# Altium schematic sheet SCH - Peripheral.SchDoc
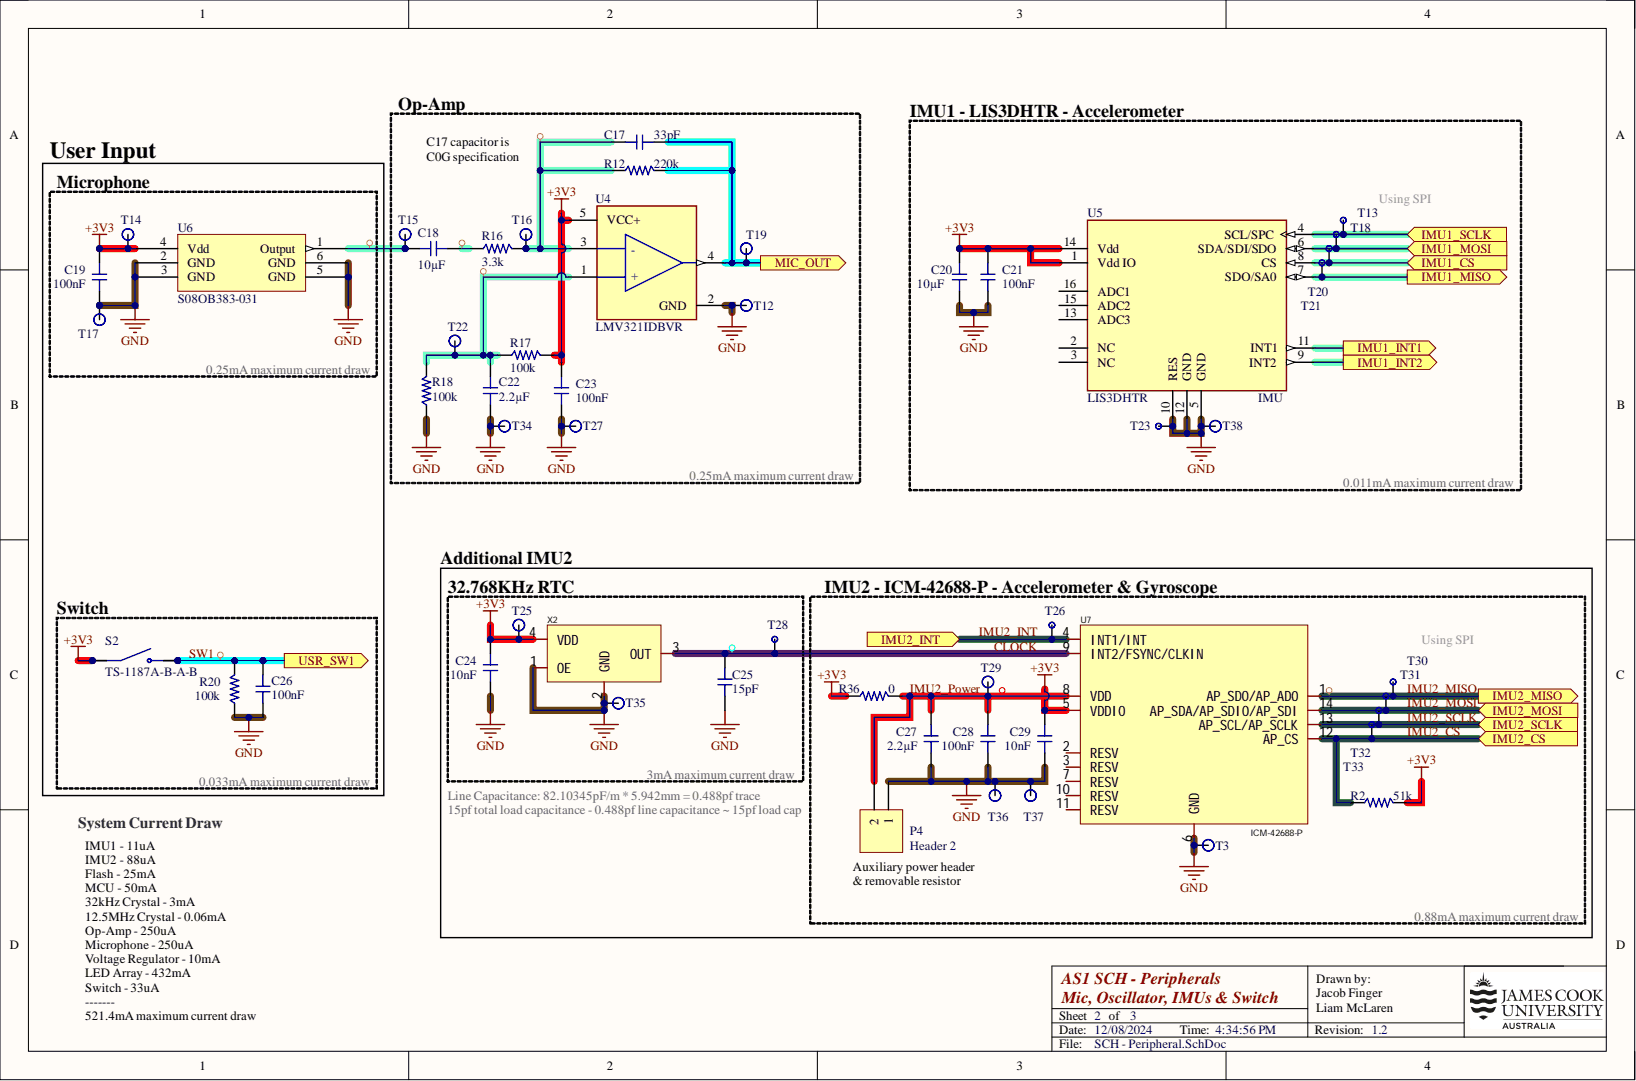
[diagram p0: SCH - Peripheral.SchDoc]

## Parts
C17  value 33pF  [CAP-0402]  (hidden comment: 0402CG330J500NT)
C18  value 10µF  [CAP-0402]  (hidden comment: CL05A106MQ5NUNC)
C19  value 100nF  [CAP-0402]  (hidden comment: CL05B104KB54PNC)
C20  value 10µF  [CAP-0402]  (hidden comment: CL05A106MQ5NUNC)
C21  value 100nF  [CAP-0402]  (hidden comment: CL05B104KB54PNC)
C22  value 2.2µF  [CAP-0402]  (hidden comment: CL05A225MQ5NSNC)
C23  value 100nF  [CAP-0402]  (hidden comment: CL05B104KB54PNC)
C24  value 10nF  [CAP-0402]  (hidden comment: CL05B103KB5NNNC)
C25  value 15pF  [CAP-0402]  (hidden comment: 0402CG150J500NT)
C26  value 100nF  [CAP-0402]  (hidden comment: CL05B104KO5NNNC)
C27  value 2.2µF  [CAP-0402]  (hidden comment: CL05A225MQ5NSNC)
C28  value 100nF  [CAP-0402]  (hidden comment: CL05B104KB54PNC)
C29  value 10nF  [CAP-0402]  (hidden comment: CL05B103KB5NNNC)
P4  Header 2  [HDR1X2]  (no BOM)
R2  value 51k  [RES-0402]  (hidden comment: 0402WGF5102TCE)
R12  value 220k  [RES-0603]  (hidden comment: 0603WAF2203T5E)
R16  value 3.3k  [RES-0402]  (hidden comment: 0402WGF3301TCE)
R17  value 100k  [RES-0402]  (hidden comment: 0402WGF1003TCE)
R18  value 100k  [RES-0402]  (hidden comment: 0402WGF1003TCE)
R20  value 100k  [RES-0402]  (hidden comment: 0402WGF1003TCE)
R36  value 0  [RES-0402]  (hidden comment: 0402WGF0000TCE)
S2  TS-1187A-B-A-B  [SW_TS-1187A-B-A-B]
T3  TP  [TestPoint]  (value not drawn)  (no BOM)
T12  TP  [TestPoint]  (value not drawn)  (no BOM)
T13  TP  [TestPoint - Small]  (value not drawn)  (no BOM)
T14  TP  [TestPoint]  (value not drawn)  (no BOM)
T15  TP  [TestPoint]  (value not drawn)  (no BOM)
T16  TP  [TestPoint]  (value not drawn)  (no BOM)
T17  TP  [TestPoint]  (value not drawn)  (no BOM)
T18  TP  [TestPoint - Small]  (value not drawn)  (no BOM)
T19  TP  [TestPoint]  (value not drawn)  (no BOM)
T20  TP  [TestPoint - Small]  (value not drawn)  (no BOM)
T21  TP  [TestPoint - Small]  (value not drawn)  (no BOM)
T22  TP  [TestPoint]  (value not drawn)  (no BOM)
T23  TP  [TestPoint - Small]  (value not drawn)  (no BOM)
T25  TP  [TestPoint]  (value not drawn)  (no BOM)
T26  TP  [TestPoint - Small]  (value not drawn)  (no BOM)
T27  TP  [TestPoint]  (value not drawn)  (no BOM)
T28  TP  [TestPoint - Small]  (value not drawn)  (no BOM)
T29  TP  [TestPoint]  (value not drawn)  (no BOM)
T30  TP  [TestPoint - Small]  (value not drawn)  (no BOM)
T31  TP  [TestPoint - Small]  (value not drawn)  (no BOM)
T32  TP  [TestPoint - Small]  (value not drawn)  (no BOM)
T33  TP  [TestPoint - Small]  (value not drawn)  (no BOM)
T34  TP  [TestPoint]  (value not drawn)  (no BOM)
T35  TP  [TestPoint]  (value not drawn)  (no BOM)
T36  TP  [TestPoint]  (value not drawn)  (no BOM)
T37  TP  [TestPoint]  (value not drawn)  (no BOM)
T38  TP  [TestPoint]  (value not drawn)  (no BOM)
U4  LMV321IDBVR  [FP-DBV0005A-MFG]
U5  LIS3DHTR  [FP-LGA-16-7983231-MFG]
U6  S08OB383-031  [Goertek_S08OB381-026]
U7  IMU IC  [LGA-14_L3.0-W2.5-P0.50-TL]  (value not drawn)
X2  HSO221S RTC  [OSC-SMD_4P-L2.5-W2.0-BL]  (value not drawn)

## Ports
IMU1_CS, IMU1_INT1, IMU1_INT2, IMU1_MISO, IMU1_MOSI, IMU1_SCLK, IMU2_CS, IMU2_INT, IMU2_MISO, IMU2_MOSI, IMU2_SCLK, MIC_OUT, USR_SW1

## Nets
+3V3: C19.2 C20.1 C21.2 C23.2 C24.2 C29.2 R2.2 R17.2 R36.1 S2.A S2.B T14.1 T25.1 U4.5(VCC+) U5.1(Vdd_IO) U5.14(Vdd) U6.4(Vdd) U7.5(VDDIO) X2.4(VDD)
GND: C19.1 C20.2 C21.1 C22.1 C23.1 C24.1 C25.1 C26.1 C27.1 C28.1 C29.1 P4.1 R18.1 R20.1 T3.1 T12.1 T17.1 T23.1 T27.1 T34.1 T35.1 T36.1 T37.1 T38.1 U4.2(GND) U5.5(GND) U5.10(RES) U5.12(GND) U6.2(GND) U6.3(GND) U6.5(GND) U6.6(GND) U7.6(GND) X2.1(OE) X2.2(GND)
CLOCK: C25.2 T28.1 U7.9(INT2/FSYNC/CLKIN) X2.3(OUT)
IMU1_CS: T20.1 U5.8(CS) ; port IMU1_CS
IMU1_INT1: U5.11(INT1) ; port IMU1_INT1
IMU1_INT2: U5.9(INT2) ; port IMU1_INT2
IMU1_MISO: T21.1 U5.7(SDO/SA0) ; port IMU1_MISO
IMU1_MOSI: T18.1 U5.6(SDA/SDI/SDO) ; port IMU1_MOSI
IMU1_SCLK: T13.1 U5.4(SCL/SPC) ; port IMU1_SCLK
IMU2_CS: R2.1 T33.1 U7.12(AP_CS) ; port IMU2_CS
IMU2_INT: T26.1 U7.4(INT1/INT) ; port IMU2_INT
IMU2_MISO: T30.1 U7.1(AP_SDO/AP_AD0) ; port IMU2_MISO
IMU2_MOSI: T31.1 U7.14(AP_SDA/AP_SDIO/AP_SDI) ; port IMU2_MOSI
IMU2_Power: C27.2 C28.2 P4.2 R36.2 T29.1 U7.8(VDD)
IMU2_SCLK: T32.1 U7.13(AP_SCL/AP_SCLK) ; port IMU2_SCLK
MIC_OUT: C17.2 R12.2 T19.1 U4.4 ; port MIC_OUT
SW1: C26.2 R20.2 S2.C S2.D ; port USR_SW1
NetC17_1: C17.1 R12.1 R16.2 T16.1 U4.3
NetC18_1: C18.1 T15.1 U6.1(Output)
NetC18_2: C18.2 R16.1
NetC22_2: C22.2 R17.1 R18.2 T22.1 U4.1

Not connected: U5 2(NC) 3(NC) 13(ADC3) 15(ADC2) 16(ADC1); U7 2(RESV) 3(RESV) 7(RESV) 10(RESV) 11(RESV)

## Library data of the parts
C17 = CMP-001-00127-3: Capacitor, Ceramic (MLCC), 33pF, ±5%, 50V, C0G, ±5%, SMD 0402; Manufacturer: FH(Guangdong Fenghua Advanced Tech); Case/Package: 0402; Category: Capacitors - MLCC; Component Kind: Standard; ComponentLink1Description: Datasheet; ComponentLink1URL: https://datasheet.lcsc.com/lcsc/1811141731_FH--Guangdong-Fenghua-Advanced-Tech-0402CG330J500NT_C1562.pdf; ComponentLink2Description: JLCPCB Part URL; ComponentLink2URL: https://jlcpcb.com/partdetail/C1562; ComponentLink3Description: LCSC Part URL; ComponentLink3URL: https://lcsc.com/product-detail/Multilayer-Ceramic-Capacitors-MLCC---SMD-SMT_FH-Guangdong-Fenghua-Advanced-Tech-FH-Guangdong-Fenghua-Advanced-Tech-0402CG330J500NT_C1562.html; Design Item ID: 0402CG330J500NT; JLCPCB Basic Part: Yes; JLCPCB Part Number: C1562; Manufacturer Part Number: 0402CG330J500NT; RoHS: Yes; Source: jlcpcb_capacitors_basic.schlib; Temperature Coefficient: C0G; Tolerance: 5%; Voltage Rating: 50V; Library Name: Finmac Systems
C18 C20 = CMP-001-00141-3: Capacitor, Ceramic (MLCC), 10uF, ±20%, 6.3V, X5R, ±20%, SMD 0402; Manufacturer: Samsung Electro-Mechanics; Case/Package: 0402; Category: Capacitors - MLCC; Component Kind: Standard; ComponentLink1Description: Datasheet; ComponentLink1URL: https://datasheet.lcsc.com/lcsc/2208231630_Samsung-Electro-Mechanics-CL05A106MQ5NUNC_C15525.pdf; ComponentLink2Description: JLCPCB Part URL; ComponentLink2URL: https://jlcpcb.com/partdetail/C15525; ComponentLink3Description: LCSC Part URL; ComponentLink3URL: https://lcsc.com/product-detail/Multilayer-Ceramic-Capacitors-MLCC---SMD-SMT_Samsung-Electro-Mechanics-Samsung-Electro-Mechanics-CL05A106MQ5NUNC_C15525.html; Design Item ID: CL05A106MQ5NUNC; JLCPCB Basic Part: Yes; JLCPCB Part Number: C15525; Manufacturer Part Number: CL05A106MQ5NUNC; RoHS: Yes; Source: jlcpcb_capacitors_basic.schlib; Temperature Coefficient: X5R; Tolerance: 20%; Voltage Rating: 6.3V; Library Name: Finmac Systems
C19 C21 C23 C28 = CMP-001-00146-3: Capacitor, Ceramic (MLCC), 100nF, ±10%, 50V, X7R, ±10%, SMD 0402; Manufacturer: Samsung Electro-Mechanics; Case/Package: 0402; Category: Capacitors - MLCC; Component Kind: Standard; ComponentLink1Description: Datasheet; ComponentLink1URL: https://datasheet.lcsc.com/lcsc/1810191222_Samsung-Electro-Mechanics-CL05B104KB54PNC_C307331.pdf; ComponentLink2Description: JLCPCB Part URL; ComponentLink2URL: https://jlcpcb.com/partdetail/C307331; ComponentLink3Description: LCSC Part URL; ComponentLink3URL: https://lcsc.com/product-detail/Multilayer-Ceramic-Capacitors-MLCC---SMD-SMT_Samsung-Electro-Mechanics-Samsung-Electro-Mechanics-CL05B104KB54PNC_C307331.html; Design Item ID: CL05B104KB54PNC; JLCPCB Basic Part: Yes; JLCPCB Part Number: C307331; Manufacturer Part Number: CL05B104KB54PNC; RoHS: Yes; Source: jlcpcb_capacitors_basic.schlib; Temperature Coefficient: X7R; Tolerance: 10%; Voltage Rating: 50V; Library Name: Finmac Systems
C22 C27 = CMP-001-00142-3: Capacitor, Ceramic (MLCC), 2.2uF, ±20%, 6.3V, X5R, ±20%, SMD 0402; Manufacturer: Samsung Electro-Mechanics; Case/Package: 0402; Category: Capacitors - MLCC; Component Kind: Standard; ComponentLink1Description: Datasheet; ComponentLink1URL: https://datasheet.lcsc.com/lcsc/1811021410_Samsung-Electro-Mechanics-CL05A225MQ5NSNC_C12530.pdf; ComponentLink2Description: JLCPCB Part URL; ComponentLink2URL: https://jlcpcb.com/partdetail/C12530; ComponentLink3Description: LCSC Part URL; ComponentLink3URL: https://lcsc.com/product-detail/Multilayer-Ceramic-Capacitors-MLCC---SMD-SMT_Samsung-Electro-Mechanics-Samsung-Electro-Mechanics-CL05A225MQ5NSNC_C12530.html; Design Item ID: CL05A225MQ5NSNC; JLCPCB Basic Part: Yes; JLCPCB Part Number: C12530; Manufacturer Part Number: CL05A225MQ5NSNC; RoHS: Yes; Source: jlcpcb_capacitors_basic.schlib; Temperature Coefficient: X5R; Tolerance: 20%; Voltage Rating: 6.3V; Library Name: Finmac Systems
C24 C29 = CMP-001-00145-3: Capacitor, Ceramic (MLCC), 10nF, ±10%, 50V, X7R, ±10%, SMD 0402; Manufacturer: Samsung Electro-Mechanics; Case/Package: 0402; Category: Capacitors - MLCC; Component Kind: Standard; ComponentLink1Description: Datasheet; ComponentLink1URL: https://datasheet.lcsc.com/lcsc/1810191223_Samsung-Electro-Mechanics-CL05B103KB5NNNC_C15195.pdf; ComponentLink2Description: JLCPCB Part URL; ComponentLink2URL: https://jlcpcb.com/partdetail/C15195; ComponentLink3Description: LCSC Part URL; ComponentLink3URL: https://lcsc.com/product-detail/Multilayer-Ceramic-Capacitors-MLCC---SMD-SMT_Samsung-Electro-Mechanics-Samsung-Electro-Mechanics-CL05B103KB5NNNC_C15195.html; Design Item ID: CL05B103KB5NNNC; JLCPCB Basic Part: Yes; JLCPCB Part Number: C15195; Manufacturer Part Number: CL05B103KB5NNNC; RoHS: Yes; Source: jlcpcb_capacitors_basic.schlib; Temperature Coefficient: X7R; Tolerance: 10%; Voltage Rating: 50V; Library Name: Finmac Systems
C25 = CMP-001-00117-3: Capacitor, Ceramic (MLCC), 15pF, ±5%, 50V, C0G, ±5%, SMD 0402; Manufacturer: FH(Guangdong Fenghua Advanced Tech); Case/Package: 0402; Category: Capacitors - MLCC; Component Kind: Standard; ComponentLink1Description: Datasheet; ComponentLink1URL: https://datasheet.lcsc.com/lcsc/1810111610_FH--Guangdong-Fenghua-Advanced-Tech-0402CG150J500NT_C1548.pdf; ComponentLink2Description: JLCPCB Part URL; ComponentLink2URL: https://jlcpcb.com/partdetail/C1548; ComponentLink3Description: LCSC Part URL; ComponentLink3URL: https://lcsc.com/product-detail/Multilayer-Ceramic-Capacitors-MLCC---SMD-SMT_FH-Guangdong-Fenghua-Advanced-Tech-FH-Guangdong-Fenghua-Advanced-Tech-0402CG150J500NT_C1548.html; Design Item ID: 0402CG150J500NT; JLCPCB Basic Part: Yes; JLCPCB Part Number: C1548; Manufacturer Part Number: 0402CG150J500NT; RoHS: Yes; Source: jlcpcb_capacitors_basic.schlib; Temperature Coefficient: C0G; Tolerance: 5%; Voltage Rating: 50V; Library Name: Finmac Systems
C26 = CMP-001-00147-3: Capacitor, Ceramic (MLCC), 100nF, ±10%, 16V, X7R, ±10%, SMD 0402; Manufacturer: Samsung Electro-Mechanics; Case/Package: 0402; Category: Capacitors - MLCC; Component Kind: Standard; ComponentLink1Description: Datasheet; ComponentLink1URL: https://datasheet.lcsc.com/lcsc/1810191219_Samsung-Electro-Mechanics-CL05B104KO5NNNC_C1525.pdf; ComponentLink2Description: JLCPCB Part URL; ComponentLink2URL: https://jlcpcb.com/partdetail/C1525; ComponentLink3Description: LCSC Part URL; ComponentLink3URL: https://lcsc.com/product-detail/Multilayer-Ceramic-Capacitors-MLCC---SMD-SMT_Samsung-Electro-Mechanics-Samsung-Electro-Mechanics-CL05B104KO5NNNC_C1525.html; Design Item ID: CL05B104KO5NNNC; JLCPCB Basic Part: Yes; JLCPCB Part Number: C1525; Manufacturer Part Number: CL05B104KO5NNNC; RoHS: Yes; Source: jlcpcb_capacitors_basic.schlib; Temperature Coefficient: X7R; Tolerance: 10%; Voltage Rating: 16V; Library Name: Finmac Systems
P4 = CMP-002-00097-1: Header, 2-Pin; Component Type: Standard; Design Item ID: Header 2; LatestRevisionDate: 17-Jul-2002; LatestRevisionNote: Re-released for DXP Platform.; Pin Count: 2; Signal Integrity: Connector; Source: Miscellaneous Connectors.SchLib; Library Name: James Cook University; Publisher: Altium Limited
R2 = CMP-009-00226-4: Resistor, 51kΩ, ±1%, 62.5mW, 50V, ±100ppm/℃, -55℃~+155℃, SMD 0402; Manufacturer: Uniroyal; Case/Package: 0402; Category: Resistors; Component Kind: Standard; ComponentLink1Description: Datasheet; ComponentLink1URL: https://datasheet.lcsc.com/lcsc/2206010100_UNI-ROYAL-Uniroyal-Elec-0402WGF5102TCE_C25794.pdf; ComponentLink2Description: JLCPCB Part URL; ComponentLink2URL: https://jlcpcb.com/partdetail/C25794; Design Item ID: 0402WGF5102TCE; JLCPCB Basic Part: Yes; JLCPCB Part Number: C25794; Manufacturer Part Number: 0402WGF5102TCE; Power Rating: 62.5mW; Source: jlcpcb_resistors_basic.schlib; Temperature Coefficient: ±100ppm/℃; Temperature Rating: -55℃~+155℃; Tolerance: 1%; Voltage Rating: 50V; Library Name: Finmac Systems
R12 = CMP-009-00277-2: Resistor, 220kΩ, ±1%, 100mW, 75V, ±100ppm/℃, -55℃~+155℃, SMD 0603; Manufacturer: Uniroyal; Case/Package: 0603; Category: Resistors; Component Kind: Standard; ComponentLink1Description: Datasheet; ComponentLink1URL: https://datasheet.lcsc.com/lcsc/2206010130_UNI-ROYAL-Uniroyal-Elec-0603WAF2203T5E_C22961.pdf; ComponentLink2Description: JLCPCB Part URL; ComponentLink2URL: https://jlcpcb.com/partdetail/C22961; Design Item ID: 0603WAF2203T5E; JLCPCB Basic Part: Yes; JLCPCB Part Number: C22961; Manufacturer Part Number: 0603WAF2203T5E; Power Rating: 100mW; Source: jlcpcb_resistors_basic.schlib; Temperature Coefficient: ±100ppm/℃; Temperature Rating: -55℃~+155℃; Tolerance: 1%; Voltage Rating: 75V; Library Name: Finmac Systems
R16 = CMP-009-00211-4: Resistor, 3.3kΩ, ±1%, 62.5mW, 50V, ±100ppm/℃, -55℃~+155℃, SMD 0402; Manufacturer: Uniroyal; Case/Package: 0402; Category: Resistors; Component Kind: Standard; ComponentLink1Description: Datasheet; ComponentLink1URL: https://datasheet.lcsc.com/lcsc/2206010045_UNI-ROYAL-Uniroyal-Elec-0402WGF3301TCE_C25890.pdf; ComponentLink2Description: JLCPCB Part URL; ComponentLink2URL: https://jlcpcb.com/partdetail/C25890; Design Item ID: 0402WGF3301TCE; JLCPCB Basic Part: Yes; JLCPCB Part Number: C25890; Manufacturer Part Number: 0402WGF3301TCE; Power Rating: 62.5mW; Source: jlcpcb_resistors_basic.schlib; Temperature Coefficient: ±100ppm/℃; Temperature Rating: -55℃~+155℃; Tolerance: 1%; Voltage Rating: 50V; Library Name: Finmac Systems
R17 R18 R20 = CMP-009-00182-4: Resistor, 100kΩ, ±1%, 62.5mW, 50V, ±100ppm/℃, -55℃~+155℃, SMD 0402; Manufacturer: Uniroyal; Case/Package: 0402; Category: Resistors; Component Kind: Standard; ComponentLink1Description: Datasheet; ComponentLink1URL: https://datasheet.lcsc.com/lcsc/2206010100_UNI-ROYAL-Uniroyal-Elec-0402WGF1003TCE_C25741.pdf; ComponentLink2Description: JLCPCB Part URL; ComponentLink2URL: https://jlcpcb.com/partdetail/C25741; Design Item ID: 0402WGF1003TCE; JLCPCB Basic Part: Yes; JLCPCB Part Number: C25741; Manufacturer Part Number: 0402WGF1003TCE; Power Rating: 62.5mW; Source: jlcpcb_resistors_basic.schlib; Temperature Coefficient: ±100ppm/℃; Temperature Rating: -55℃~+155℃; Tolerance: 1%; Voltage Rating: 50V; Library Name: Finmac Systems
R36 = CMP-009-00178-4: Resistor, 0Ω, ±1%, 62.5mW, 50V, ±800ppm/℃, -55℃~+155℃, SMD 0402; Manufacturer: Uniroyal; Case/Package: 0402; Category: Resistors; Component Kind: Standard; ComponentLink1Description: Datasheet; ComponentLink1URL: https://datasheet.lcsc.com/lcsc/2206010216_UNI-ROYAL-Uniroyal-Elec-0402WGF0000TCE_C17168.pdf; ComponentLink2Description: JLCPCB Part URL; ComponentLink2URL: https://jlcpcb.com/partdetail/C17168; Design Item ID: 0402WGF0000TCE; JLCPCB Basic Part: Yes; JLCPCB Part Number: C17168; Manufacturer Part Number: 0402WGF0000TCE; Power Rating: 62.5mW; Source: jlcpcb_resistors_basic.schlib; Temperature Coefficient: ±800ppm/℃; Temperature Rating: -55℃~+155℃; Tolerance: 1%; Voltage Rating: 50V; Library Name: Finmac Systems
S2 = CMP-015-000000-1: SPST 50mA @ 12VDC Top Actuated Round Button 160gf SMD Tactile Switches RoHS; Availability: Unavailable; Component Kind: Standard; Component Type: Standard; Design Item ID: TS-1187A-B-A-B; JLCPCB Part: C318884; MP: TS-1187A-B-A-B; Package: None; Pin Count: 4; Price: None; Source: TS-1187A-B-A-B.SchLib; Library Name: Finmac Systems
T3 T12 T14-T17 T19 T22 T25 T27 T29 T34-T38 = TestPoint: Library Name: DatumPenLibrary.SchLib
T13 T18 T20 T21 T23 T26 T28 T30-T33 = TestPoint Small: Library Name: DatumPenLibrary.SchLib
U4 = CMP-00012-2: General Purpose Amplifier 1 Circuit Rail-to-Rail SOT-23-5; Bandwidth: 1MHz; Common Mode Rejection Ratio: 50dB; Input Bias Current: 250nA; Input Offset Voltage (Vos): 7mV; Max Supply Voltage: 5.5V; Min Supply Voltage: 2.7V; Nominal Supply Current: 250uA; Number of Amplifiers: 1; Operating Supply Current: 130uA; Output Current: 160mA; Slew Rate: 1V/µs; Voltage Gain: 100dB; Amplifier Type: GENERAL PURPOSE; Case/Package: SOT-23-5; Component Kind: Standard; Contact Plating: Tin,Matte; Gain Bandwidth Product: 1MHz; JLCPCB Part: C7972; Lead Free: Lead Free; Max Operating Temperature: 125°C; Min Operating Temperature: -40°C; Mount: Surface Mount; Number of Channels: 1; Number of Circuits: 1; Number of Elements: 1; Number of Pins: 5; Output Current per Channel: 160mA; Package Quantity: 1; Packaging: Tape and Reel; Power Supply Rejection Ratio (PSRR): 50dB; Radiation Hardening: No; REACH SVHC: No SVHC; RoHS Compliant: Yes; Series: -; Supply Current: 250uA; Technology: BICMOS; Unity Gain Bandwidth Product: 1MHz; Library Name: SCH_LIB_Assignment1.SchLib
U5 = CMP-00010-2: ACCEL 2-16G I2C/SPI 16LGA; Axis: X, Y, Z; Bandwidth: 5kHz; Interface: I2C; Max Supply Voltage: 3.6V; Min Supply Voltage: 1.71V; Operating Supply Current: 11uA; Operating Supply Voltage: 2.5V; Output Type: Digital; Resolution: 16b; Sensor Type: 3 Axis; Case/Package: LGA; Component Kind: Standard; Contact Plating: Gold; Depth: 3mm; Height: 1mm; JLCPCB Part: C15134; Lead Free: Lead Free; Length: 3mm; Max Operating Temperature: 85°C; Max Supply Voltage (DC): 3.6V; Min Operating Temperature: -40°C; Min Supply Voltage (DC): 1.71V; Number of Pins: 16; Package Quantity: 1; Packaging: Tape and Reel; Radiation Hardening: No; REACH SVHC: No SVHC; RoHS Compliant: Yes; Series: -; Width: 3mm; Library Name: SCH_LIB_Assignment1.SchLib
U6 = CMP-00027-00000-1: MEMS Microphone SMD 3.76x3x1.1mm; Component Kind: Standard; JLCPCB Part: C233789; Library Name: SCH_LIB_Assignment1.SchLib
U7 = ICM-42688-P: ICM-42688-P LGA-14(2.5x3) Attitude Sensor/Gyroscope; Manufacturer: TDK InvenSense(应美盛); Add into BOM: yes; Sensor Type: 加速度计陀螺仪; Component Kind: Standard; Convert to PCB: yes; Datasheet: https://atta.szlcsc.com/upload/public/pdf/source/20210703/CF24204867ACE5B754A2B035F23E5931.pdf; Device: ICM-42688-P; JLCPCB Part #: C1850418; JLCPCB Part Class: Extended Part; LCSC Part #: C1850418; LCSC Part Name: ICM-42688-P; Manufacturer Part: ICM-42688-P; Supplier: LCSC; Supplier Footprint: LGA-14(2.5x3); Supplier Part: C1850418; Symbol: ICM-42688-P; Library Name: DatumPenLibrary.SchLib
X2 = S2H32768KF3CHC-IT: HSO221S RTC - 3.3V 3mA ±30ppm 32.768kHz SMD2520-4P Oscillators ROHS; Manufacturer: HELE(台湾加高); Add into BOM: yes; Component Kind: Standard; Convert to PCB: yes; Datasheet: https://atta.szlcsc.com/upload/public/pdf/source/20190430/C253914_C770A64EFF6E6E62846100D666617C6C.pdf; Device: S2H32768KF3CHC-IT; Frequency Stability(Full temperature range): ±30ppm; JLCPCB Part #: C253914; JLCPCB Part Class: Extended Part; LCSC Part #: C253914; LCSC Part Name: S2H32768KF3CHC-IT; Manufacturer Part: S2H32768KF3CHC-IT; Operating Temperature: -30℃~+85℃; Supplier: LCSC; Supplier Footprint: SMD2520-4P; Supplier Part: C253914; Supply Current (Max): 3mA; Supply Voltage: 3.3V; Symbol: S2H32768KF3CHC-IT; Value: 32.768kHz; Library Name: DatumPenLibrary.SchLib
